# Altium schematic sheet Ada.SchDoc(Ada)
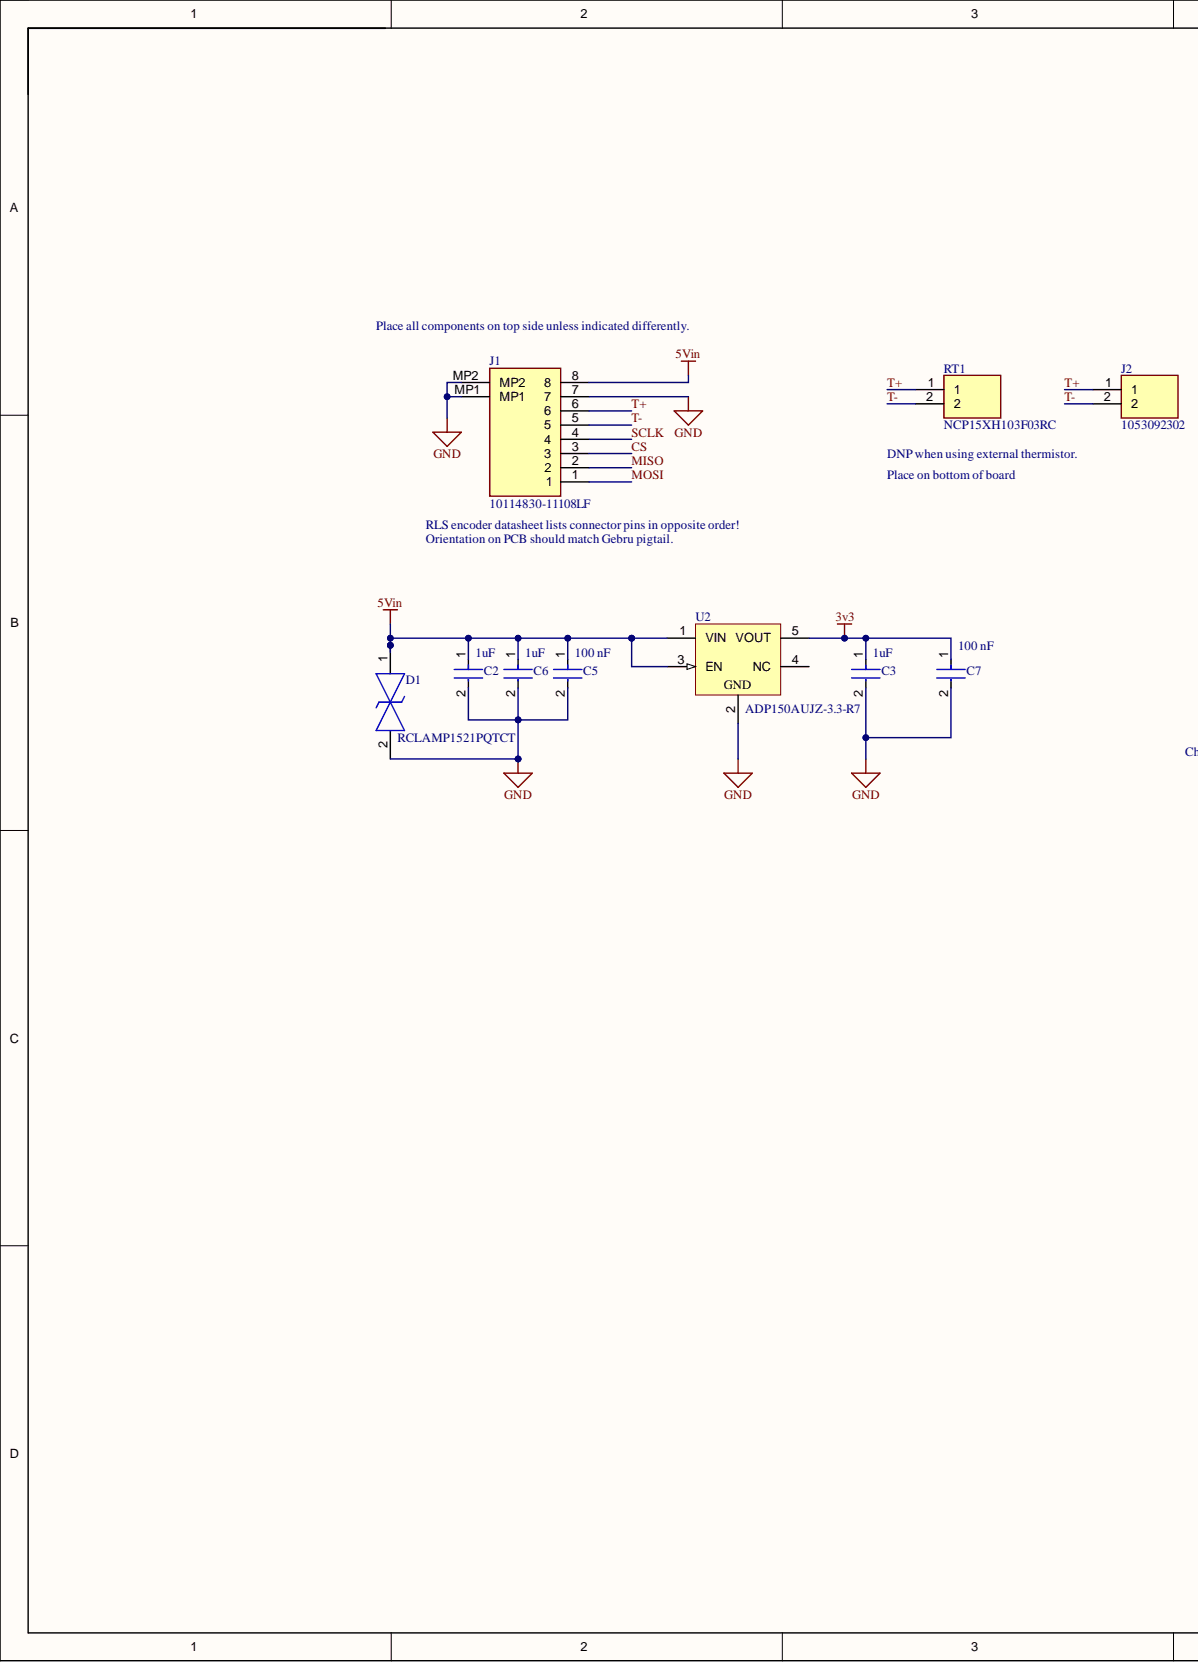
[diagram p0: Ada.SchDoc, left]
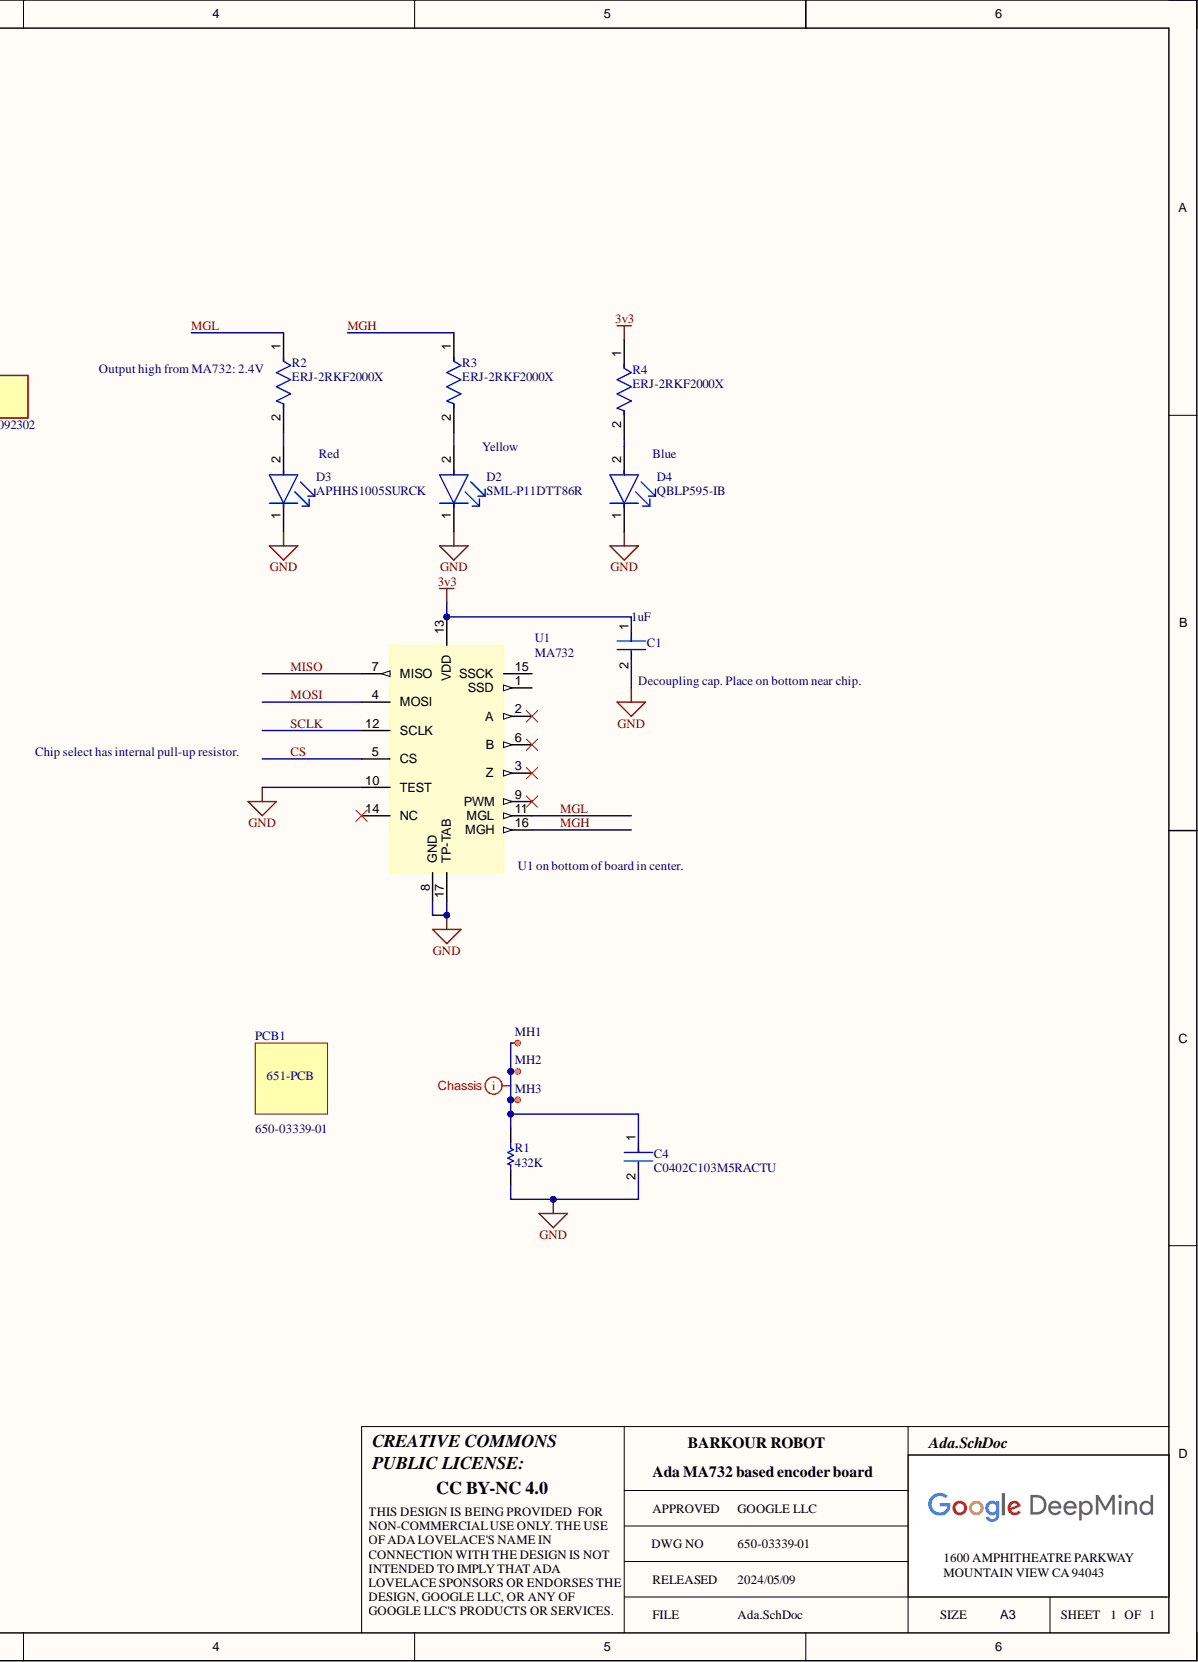
[diagram p1: Ada.SchDoc, right]

## Parts
C1  Capacitance: 1uF  [FP-CL03-IPC_A]  (hidden comment: CL03A105MQ3CSNC)
C2  Capacitance: 1uF  [FP-CL03-IPC_A]  (hidden comment: CL03A105MQ3CSNC)
C3  Capacitance: 1uF  [FP-CL03-IPC_A]  (hidden comment: CL03A105MQ3CSNC)
C4  C0402C103M5RACTU  [FP-C0402-BB-MFG]
C5  value 100 nF  Capacitance: 100 nF  [FP-CC0402-MFG]  (hidden comment: CC0402KRX5R9BB104)
C6  Capacitance: 1uF  [FP-CL03-IPC_A]  (hidden comment: CL03A105MQ3CSNC)
C7  value 100 nF  Capacitance: 100 nF  [FP-CC0402-MFG]  (hidden comment: CC0402KRX5R9BB104)
D1  RCLAMP1521PQTCT  [RCLAMP1521PQTCT]
D2  SML-P11DTT86R  [FP-SML-P11-MFG]
D3  APHHS1005SURCK  Color: Red  [FP-APHHS1005SURCK-MFG]
D4  QBLP595-IB  Color: Blue  [FP-QBLP595-IB-MFG]
J1  10114830-11108LF  [1011483011108LF]
J2  1053092302  [105309YY02_U]
MH1  MH  [MH_1.6MM]  (no BOM)
MH2  MH  [MH_1.6MM]  (no BOM)
MH3  MH  [MH_1.6MM]  (no BOM)
PCB1  PCB  GPN: 650-03339-01  (mechanical)
R1  432K  [RESC1005X40X25NL05T10]
R2  ERJ-2RKF2000X  [FP-ERJ2RK-IPC_A]
R3  ERJ-2RKF2000X  [FP-ERJ2RK-IPC_A]
R4  ERJ-2RKF2000X  [FP-ERJ2RK-IPC_A]
RT1  NCP15XH103F03RC  [THRMC1005X55N]
U1  Mfg P/N: MA732  [QFN50P300X300X100-16-TP]  (hidden comment: SENSOR_MPS_MA732)
U2  ADP150AUJZ-3.3-R7  [ADI-UJ-5_M]

## Nets
3v3: C1.1 C3.1 C7.1 R4.1 U1.13(VDD) U2.5(VOUT)
5Vin: C2.1 C5.1 C6.1 D1.1 J1.8 U2.1(VIN) U2.3(EN)
GND: C1.2 C2.2 C3.2 C4.2 C5.2 C6.2 C7.2 D1.2 D2.1 D3.1 D4.1 J1.7 J1.MP1 J1.MP2 R1.1 U1.8(GND) U1.10(TEST) U1.17(TP-TAB) U2.2
CS: J1.3 U1.5(CS)
MGH: R3.1 U1.16(MGH)
MGL: R2.1 U1.11(MGL)
MISO: J1.2 U1.7(MISO)
MOSI: J1.1 U1.4(MOSI)
SCLK: J1.4 U1.12(SCLK)
T+: J1.6 J2.1 RT1.1
T-: J1.5 J2.2 RT1.2
NetC4_1: C4.1 MH1.1 MH2.1 MH3.1 R1.2
NetD2_2: D2.2 R3.2
NetD3_2: D3.2 R2.2
NetD4_2: D4.2 R4.2

Not connected: U1 1(SSD) 2(A) 3(Z) 6(B) 9(PWM) 14(NC) 15(SSCK); U2 4(NC)

## Library data of the parts
C1-C3 C6 = CMP-13271-003873-1: Cap Ceramic 1uF 6.3V X5R ±20% Pad SMD 0201 +85°C T/R; Case Code (Imperial): 0201; Case Code (Metric): 0603; Case/Package: 0201; Component Kind: Standard; Depth: 0.3mm; Dielectric: X5R; Lead Free: Lead Free; Length: 0.024inch; Library Name: Altium Content Vault; Max Operating Temperature: 85°C; Min Operating Temperature: -55°C; Mount: Surface Mount; Package Quantity: 1; Packaging: Tape and Reel; Thickness: 0.013inch; Tolerance: 20%; Voltage Rating: 6.3V; Width: 0.012inch
C4 = CMP-1034-01887-3: CAP CER 10000PF 50V 20% X7R 0402; Manufacturer: Kemet; Capacitance: 10,000 pF; Material: Ceramic; Temperature Coefficient: 15%; Tolerance: 0.2; Value: 10,000 pF; Automotive: No; Category: Cap; Component Kind: Standard; ComponentLink2Description: Datasheet; ComponentLink2URL: https://content.kemet.com/datasheets/KEM_C1002_X7R_SMD.pdf; Device Class L1: Passive Components; Device Class L2: Capacitors; Device Class L3: Ceramic Capacitors; Digikey Description: CAP CER 10000PF 50V 20% X7R 0402; Height: 0.55mm; Ipc Land Pattern Name: CAPC10050X50; Lead Free: Yes; Library Name: Altium Content Vault; Manufacturer Part Number: C0402C103M5RACTU; Mouser Description: Cap Ceramic 0.01uF 50V X7R 20% SMD 1.0 x 0.5 mm 125 C Paper T/R; Octopart: https://octopart.com/c0402c103m5ractu-kemet-17125571; Package: C0402-BB; RoHS: TRUE; Temperature: -55°C to +125°C; Temperature Characteristic: X7R; Temperature Range High: +125°C; Temperature Range Low: -55°C; Voltage Rating: 50 V
C5 C7 = CMP-03422-000742-1: CAP CER 0.1UF 50V X5R 0402; Manufacturer: YAGEO; Automotive Grade: NA; Material: Ceramic; Temperature Coefficient: 30ppm/°C; Tolerance: 0.1; Automotive: No; Category: Cap; Component Kind: Standard; ComponentLink2Description: Datasheet; ComponentLink2URL: http://www.yageo.com/documents/recent/UPY-GP_NP0_16V-to-50V_16.pdf; Device Class L1: Passive Components; Device Class L2: Capacitors; Device Class L3: Ceramic Capacitors; Digikey Description: CAP CER 0.1UF 50V X5R 0402; Footprint Url: http://www.yageo.com/exep/pages/download/literatures/UPY-C_GEN_24.pdf; Height: 0.55mm; Ipc Land Pattern Name: CAPC10050X50; Lead Free: Yes; Library Name: Altium Content Vault; Manufacturer Part Number: CC0402KRX5R9BB104; Octopart: https://octopart.com/cc0402krx5r9bb104-yageo-33978688; Package: CC0402; RoHS: TRUE; Temperature: -55°C to +125°C; Temperature Characteristic: X5R; Temperature Range High: +125°C; Temperature Range Low: -55°C; Voltage Rating: 50 V
D1 = RCLAMP1521PQTCT: TVS Diode (Bi-directional); Manufacturer_Name: SEMTECH; Arrow Part Number: RCLAMP1521PQTCT; Arrow Price/Stock: https://www.arrow.com/en/products/rclamp1521pqtct/semtech; Component Kind: Standard; Datasheet Link: https://www.arrow.com/en/products/rclamp1521pqtct/semtech; Height: 0.55mm; Manufacturer_Part_Number: RCLAMP1521PQTCT; Mouser Part Number: 947-RCLAMP1521PQTCT; Mouser Price/Stock: https://www.mouser.co.uk/ProductDetail/Semtech/RCLAMP1521PQTCT/?qs=rBWM4%252BvDhIejX164RfHkPw%3D%3D
D2 = CMP-08952-000022-1: LED ORANGE CLEAR 1006 SMD; Manufacturer: Rohm; Forward Current: 1mA; Forward Voltage: 1.9V; Led Orientation: Top View; Luminous Intensity: 7.3mcd; Power Dissipation: 52mW; Reverse Voltage: 5V; Wavelength: 605nm; Automotive: No; Category: Diode; Colour: Orange; Component Kind: Standard; ComponentLink2Description: Datasheet; ComponentLink2URL: https://d1d2qsbl8m0m72.cloudfront.net/en/products/databook/datasheet/opto/led/chip_mono/sml-p11-e.pdf; Device Class L1: Optoelectronics; Device Class L2: LEDs; Device Class L3: unset; Digikey Description: LED ORANGE CLEAR 1006 SMD; Height: 0.25mm; Lead Free: Yes; Library Name: Altium Content Vault; Manufacturer Part Number: SML-P11DTT86R; Mouser Description: LED Uni-Color Orange 2-Pin Chip 0402(1006Metric) T/R; Octopart: https://octopart.com/sml-p11dtt86r-rohm-34112313; Package: SML-P11; RoHS: TRUE; Temperature: -40°C to +85°C; Temperature Range High: +85°C; Temperature Range Low: -40°C
D3 = CMP-2000-05787-2: LED RED CLEAR CHIP SMD; Dominant Wavelength: 630nm; Forward Current: 20mA; Forward Voltage: 1.95V; Luminous Intensity: 220mcd; Number of LEDs: 1; Viewing Angle: 120°; Wavelength: 635nm; Case Code (Imperial): 0402; Case Code (Metric): 1005; Case/Package: 0402; Component Kind: Standard; Height: 0.6mm; Illumination Color: Red; Lead Free: Lead Free; Length: 1mm; Lens Color: Clear; Lens Style: Clear; Library Name: Altium Content Vault; Max Operating Temperature: 85°C; Min Operating Temperature: -40°C; Mount: Surface Mount; Number of Pins: 2; Package Quantity: 2000; Packaging: Tape and Reel; Test Current: 20mA; Width: 0.5mm
D4 = CMP-113271-000002-1: LED BLUE CLEAR 0402 SMD; Dominant Wavelength: 465nm; Forward Voltage: 3.1V; Lens Color: Colorless; Lens Size: 0.5mm; Lens Style: Flat; Luminous Intensity: 85mcd; Test Current: 20mA; Viewing Angle: 140°; Case Code (Imperial): 0402; Case Code (Metric): 1005; Case/Package: 0402; Component Kind: Standard; Height: 0.6mm; Length: 1mm; Lens Transparency: Clear; Library Name: Altium Content Vault; Mount: Surface Mount; Packaging: Tape and Reel; Width: 0.5mm
J1 = 10114830-11108LF: Connector; Manufacturer_Name: Amphenol; Component Kind: Standard; Datasheet Link: https://cdn.amphenol-icc.com/media/wysiwyg/files/drawing/10114830.pdf; Height: 3.4mm; Library Name: SamacSys.SchLib; Manufacturer_Part_Number: 10114830-11108LF; Mouser Part Number: 649-10114830-11108LF; Mouser Price/Stock: https://www.mouser.co.uk/ProductDetail/Amphenol-FCI/10114830-11108LF?qs=SqJKR5Mmryf8jaiAqFrwwQ%3D%3D
J2 = 1053092302: Connector; Manufacturer_Name: Molex; Component Kind: Standard; Datasheet Link: https://www.molex.com/pdm_docs/sd/1053091102_sd.pdf; Height: 10.51mm; Library Name: SamacSys.SchLib; Manufacturer_Part_Number: 1053092302
MH1-MH3 = 144: MOUNTING HOLE, M1.6, 4.0mm PAD; Database Table Name: Miscellaneous; ID: 144; Library Name: AltiumReleaseLibDB.DBLib; Status: Released
PCB1 = 110: Database Table Name: Miscellaneous; FindNumber: 010; Level: 1; Org: ORG - REP; Description: PCB; ID: 110; ItemNumber: 650-03339-01; Library Name: AltiumReleaseLibDB.DBLib; MFG P/N: 650-03339-01; ProductLine: Proxy; PROXY: 650-03339-01; Status: In Development; Type: PCB
R1 = CMP-2002-07684-1: Manufacturer: Yageo; Part Number: RC0402FR-07432KL; Component Kind: Standard; Library Name: Altium Content Vault
R2-R4 = CMP-2002-00757-2: RES SMD 200 OHM 1% 1/10W 0402; Max Power Dissipation: 100mW; Resistance: 200R; Temperature Coefficient: 100ppm/°C; Voltage Rating: 50V; Case Code (Imperial): 0402; Case Code (Metric): 1005; Case/Package: 0402; Component Kind: Standard; Construction: Rectangular; Contact Plating: Tin; Depth: 0.5mm; Features: Automotive AEC-Q200; Height: 0.35mm; Lead Free: Lead Free; Length: 1mm; Library Name: Altium Content Vault; Max Operating Temperature: 155°C; Military Standard: Not; Min Operating Temperature: -55°C; Mount: Surface Mount; Number of Terminations: 2; Package Quantity: 1; Packaging: Tape and Reel; Power Rating: 100mW; Radiation Hardening: No; REACH SVHC: No SVHC; Resistance Tolerance: 1%; Resistor Type: Thick Film; RoHS Compliant: Yes; Series: ERJ; Size Code: 0402; Technology: THICK FILM; Termination: SMD/SMT; Tolerance: 1%; Voltage Rating (DC): 50V; Width: 0.02inch
RT1 = NCP15XH103F03RC: Thermistor; Manufacturer_Name: Murata Electronics; Arrow Part Number: NCP15XH103F03RC; Arrow Price/Stock: https://www.arrow.com/en/products/ncp15xh103f03rc/murata-manufacturing?region=nac; Component Kind: Standard; Datasheet Link: https://datasheet.datasheetarchive.com/originals/distributors/Datasheets_SAMA/616ff9b704eea4ec1739d2fdac2a983c.pdf; Height: 0.55mm; Manufacturer_Part_Number: NCP15XH103F03RC; Mouser Part Number: 81-NCP15XH103F03RC; Mouser Price/Stock: https://www.mouser.co.uk/ProductDetail/Murata-Electronics/NCP15XH103F03RC?qs=fKZN0AlWj%2F2mA3qn9WuQPw%3D%3D
U1 = 165: SENSOR, ANGLE, 9 BIT TO 14 BIT RESOLUTION, 14-BIT PWM OUTPUT, 3.3V, 12mA, QFN-16; Database Table Name: Sensors; Mfg: MPS; SENSOR TYPE: Angle Sensor; Category: Sensors; Component Kind: Standard; ComponentLink1Description: Datasheet; ComponentLink1URL: https://www.monolithicpower.com/en/documentview/productdocument/index/version/2/document_type/Datasheet/lang/en/sku/MA732/document_id/5009/; Height: 1mm; HEIGHT (MAX): 1mm; ID: 165; Library Name: AltiumReleaseLibDB.DBLib; Mounting Type: Surface Mount; OPERATING TEMPERATURE: -40°C to +125°C; Package: QFN-16; RoHS Compliant: Yes; Status: Released; VOLTAGE - SUPPLY: 3.3V
U2 = CMP-0786-00394-1: Ultralow Noise, 150 mA CMOS Linear Regulator, 2.2 to 5.5 V Vin, 3.3 V Vout, Industrial, 5-pin SOT23 (UJ-5), Reel; Manufacturer: Analog Devices; Iout max: 150mA; Preset Vout Values (V): 3.3; Supply Current IGND @ Small Load: 10uA; Vin (max) (V): 5.5; Vin (min) (V): 2.2; Code_JEDEC: MO-193-AB; Component Kind: Standard; ComponentLink1Description: Manufacturer URL; ComponentLink1URL: http://www.analog.com/en/index.html; ComponentLink2Description: Datasheet; ComponentLink2URL: http://www.analog.com/static/imported-files/data_sheets/ADP150.pdf; ComponentLink3Description: Package Specification; ComponentLink3URL: http:/www.analog.com/static/imported-files/packages/PKG_PDF/TSOT(UJ)/UJ_5.pdf; ComponentLink4Description: URL Link1; ComponentLink4URL: Product URL:http://www.analog.com/en/ADP150/productSearch.html; DatasheetVersion: Rev. A; Library Name: Altium Content Vault; Mounting Technology: Surface Mount; PackageDescription: 5-Pin Thin Small Outline Transistor Package, Body 2.9 x 1.6 mm, Pitch 0.95 mm; PackageReference: UJ-5; PackageVersion: 100708-A, 10/2008; Packing: Reel; PartNumber: ADP150AUJZ-3.3-R7
